# Altium schematic sheet FEV-60_IO_Board.SchDoc
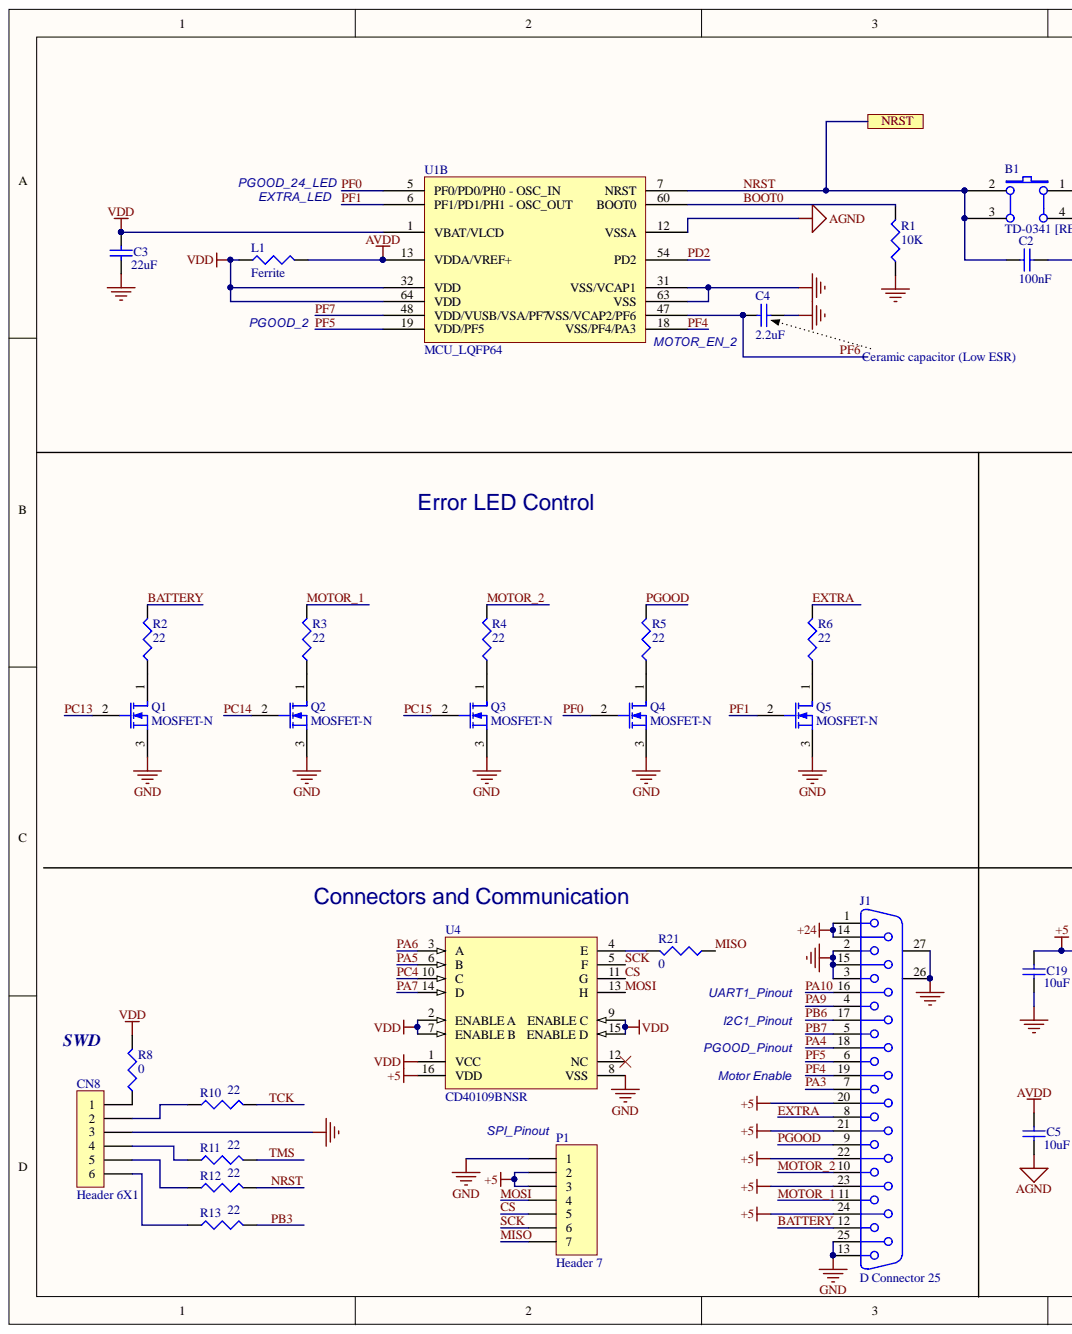
[diagram p0: FEV-60_IO_Board.SchDoc, left]
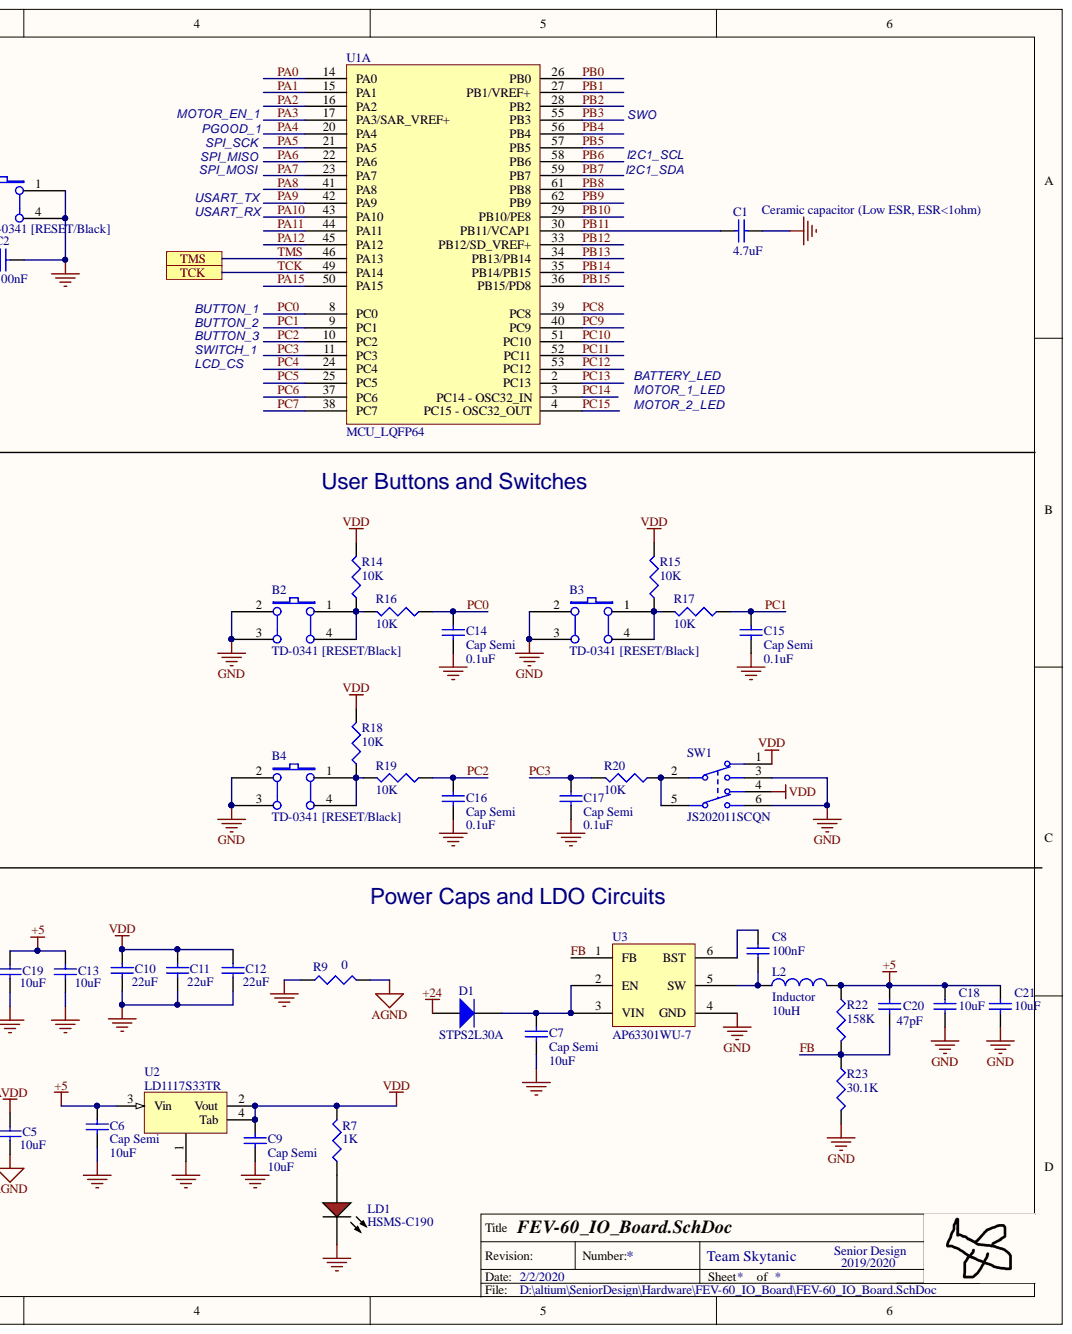
[diagram p1: FEV-60_IO_Board.SchDoc, right]

## Parts
B1  TD-0341 [RESET/Black]  [Button]
B2  TD-0341 [RESET/Black]  [Button]
B3  TD-0341 [RESET/Black]  [Button]
B4  TD-0341 [RESET/Black]  [Button]
C1  value 4.7uF  [1608[0603]]  (hidden comment: Cap Semi)
C2  value 100nF  [1608[0603]]  (hidden comment: Cap Semi)
C3  value 22uF  [1608[0603]]  (hidden comment: Cap Semi)
C4  value 2.2uF  [1608[0603]]  (hidden comment: Cap Semi)
C5  value 10uF  [1608[0603]]  (hidden comment: Cap Semi)
C6  Cap Semi  value 10uF  [1608[0603]]
C7  Cap Semi  value 10uF  [1608[0603]]
C8  value 100nF  [1608[0603]]  (hidden comment: Cap Semi)
C9  Cap Semi  value 10uF  [1608[0603]]
C10  value 22uF  [1608[0603]]  (hidden comment: Cap Semi)
C11  value 22uF  [1608[0603]]  (hidden comment: Cap Semi)
C12  value 22uF  [1608[0603]]  (hidden comment: Cap Semi)
C13  value 10uF  [1608[0603]]  (hidden comment: Cap Semi)
C14  Cap Semi  value 0.1uF  [1608[0603]]
C15  Cap Semi  value 0.1uF  [1608[0603]]
C16  Cap Semi  value 0.1uF  [1608[0603]]
C17  Cap Semi  value 0.1uF  [1608[0603]]
C18  value 10uF  [1608[0603]]  (hidden comment: Cap Semi)
C19  value 10uF  [1608[0603]]  (hidden comment: Cap Semi)
C20  value 47pF  [1608[0603]]  (hidden comment: Cap Semi)
C21  value 10uF  [1608[0603]]  (hidden comment: Cap Semi)
CN8  Header 6X1  [HDR1X6]
D1  STPS2L30A  [STPS2L30A]
J1  D Connector 25  [DSUB1.385-2H25A]
L1  Ferrite  [J1-0603]
L2  Inductor  value 10uH  [74404084100]
LD1  HSMS-C190  [AVAG-HSMX-C190_V]
P1  Header 7  [HDR1X7]
Q1  MOSFET-N  [RK7002BMT116]
Q2  MOSFET-N  [RK7002BMT116]
Q3  MOSFET-N  [RK7002BMT116]
Q4  MOSFET-N  [RK7002BMT116]
Q5  MOSFET-N  [RK7002BMT116]
R1  value 10K  [J1-0603]  (hidden comment: Res3)
R2  value 22  [J1-0603]  (hidden comment: Res3)
R3  value 22  [J1-0603]  (hidden comment: Res3)
R4  value 22  [J1-0603]  (hidden comment: Res3)
R5  value 22  [J1-0603]  (hidden comment: Res3)
R6  value 22  [J1-0603]  (hidden comment: Res3)
R7  value 1K  [J1-0603]  (hidden comment: Res3)
R8  value 0  [J1-0603]  (hidden comment: Res3)
R9  value 0  [J1-0603]  (hidden comment: Res3)
R10  value 22  [J1-0603]  (hidden comment: Res3)
R11  value 22  [J1-0603]  (hidden comment: Res3)
R12  value 22  [J1-0603]  (hidden comment: Res3)
R13  value 22  [J1-0603]  (hidden comment: Res3)
R14  value 10K  [J1-0603]  (hidden comment: Res3)
R15  value 10K  [J1-0603]  (hidden comment: Res3)
R16  value 10K  [J1-0603]  (hidden comment: Res3)
R17  value 10K  [J1-0603]  (hidden comment: Res3)
R18  value 10K  [J1-0603]  (hidden comment: Res3)
R19  value 10K  [J1-0603]  (hidden comment: Res3)
R20  value 10K  [J1-0603]  (hidden comment: Res3)
R21  value 0  [J1-0603]  (hidden comment: Res3)
R22  value 158K  [J1-0603]  (hidden comment: Res3)
R23  value 30.1K  [J1-0603]  (hidden comment: Res3)
SW1  JS202011SCQN  [CKCP-JS202011SCQN-6_V]
U1  MCU_LQFP64  [STM32F030R8]  (parts A,B)
U2  LD1117S33TR  [LD1117S33TR]
U3  AP63301WU-7  [AP63301WU-7]
U4  CD40109BNSR  [NS0016A_N]

## Ports
NRST, TCK, TMS

## Nets
+5: C6.2 C13.2 C18.2 C19.2 C20.2 C21.2 J1.20 J1.21 J1.22 J1.23 J1.24 L2.2 P1.2 P1.3 R22.1 U2.3(Vin) U4.16(VDD)
+24: D1.1 J1.1 J1.14
AGND: C5.1 R9.2 U1.12(VSSA)
AVDD: C5.2 L1.2 U1.13(VDDA/VREF+)
GND: B1.1 B1.4 B2.2 B2.3 B3.2 B3.3 B4.2 B4.3 C1.1 C2.1 C3.1 C4.1 C6.1 C7.1 C9.1 C10.1 C11.1 C12.1 C13.1 C14.1 C15.1 C16.1 C17.1 C18.1 C19.1 C21.1 CN8.3 J1.2 J1.3 J1.13 J1.15 J1.25 J1.26 J1.27 LD1.2 P1.1 Q1.3 Q2.3 Q3.3 Q4.3 Q5.3 R1.1 R9.1 R23.2 SW1.3 SW1.6 U1.31(VSS/VCAP1) U1.63(VSS) U2.1 U3.4(GND) U4.8(VSS)
VDD: C3.2 C9.2 C10.2 C11.2 C12.2 L1.1 R7.2 R8.2 R14.2 R15.2 R18.2 SW1.1 SW1.4 U1.1(VBAT/VLCD) U1.32(VDD) U1.64(VDD) U2.2(Vout) U2.4(Tab) U4.1(VCC) U4.2(ENABLE_A) U4.7(ENABLE_B) U4.9(ENABLE_C) U4.15(ENABLE_D)
BATTERY: J1.12 R2.2
BOOT0: R1.2 U1.60(BOOT0)
CS: P1.5 U4.11(G)
EXTRA: J1.8 R6.2
FB: C20.1 R22.2 R23.1 U3.1(FB)
MISO: P1.7 R21.1
MOSI: P1.4 U4.13(H)
MOTOR_1: J1.11 R3.2
MOTOR_2: J1.10 R4.2
NRST: B1.2 B1.3 C2.2 R12.2 U1.7(NRST) ; port NRST
PA0: U1.14(PA0)
PA1: U1.15(PA1)
PA2: U1.16(PA2)
PA3: J1.7 U1.17(PA3/SAR_VREF+)
PA4: J1.18 U1.20(PA4)
PA5: U1.21(PA5) U4.6(B)
PA6: U1.22(PA6) U4.3(A)
PA7: U1.23(PA7) U4.14(D)
PA8: U1.41(PA8)
PA9: J1.4 U1.42(PA9)
PA10: J1.16 U1.43(PA10)
PA11: U1.44(PA11)
PA12: U1.45(PA12)
PA15: U1.50(PA15)
PB0: U1.26(PB0)
PB1: U1.27(PB1/VREF+)
PB2: U1.28(PB2)
PB3: R13.2 U1.55(PB3)
PB4: U1.56(PB4)
PB5: U1.57(PB5)
PB6: J1.17 U1.58(PB6)
PB7: J1.5 U1.59(PB7)
PB8: U1.61(PB8)
PB9: U1.62(PB9)
PB10: U1.29(PB10/PE8)
PB11: C1.2 U1.30(PB11/VCAP1)
PB12: U1.33(PB12/SD_VREF+)
PB13: U1.34(PB13/PB14)
PB14: U1.35(PB14/PB15)
PB15: U1.36(PB15/PD8)
PC0: C14.2 R16.1 U1.8(PC0)
PC1: C15.2 R17.1 U1.9(PC1)
PC2: C16.2 R19.1 U1.10(PC2)
PC3: C17.2 R20.2 U1.11(PC3)
PC4: U1.24(PC4) U4.10(C)
PC5: U1.25(PC5)
PC6: U1.37(PC6)
PC7: U1.38(PC7)
PC8: U1.39(PC8)
PC9: U1.40(PC9)
PC10: U1.51(PC10)
PC11: U1.52(PC11)
PC12: U1.53(PC12)
PC13: Q1.2 U1.2(PC13)
PC14: Q2.2 U1.3(PC14_-_OSC32_IN)
PC15: Q3.2 U1.4
PD2: U1.54(PD2)
PF0: Q4.2 U1.5
PF1: Q5.2 U1.6
PF4: J1.19 U1.18(VSS/PF4/PA3)
PF5: J1.6 U1.19(VDD/PF5)
PF6: C4.2 U1.47(VSS/VCAP2/PF6)
PF7: U1.48(VDD/VUSB/VSA/PF7)
PGOOD: J1.9 R5.2
SCK: P1.6 U4.5(F)
TCK: R10.2 U1.49(PA14) ; port TCK
TMS: R11.2 U1.46(PA13) ; port TMS
NetB2_1: B2.1 B2.4 R14.1 R16.2
NetB3_1: B3.1 B3.4 R15.1 R17.2
NetB4_1: B4.1 B4.4 R18.1 R19.2
NetC7_2: C7.2 D1.2 U3.2(EN) U3.3(VIN)
NetC8_1: C8.1 L2.1 U3.5(SW)
NetC8_2: C8.2 U3.6(BST)
NetCN8_1: CN8.1 R8.1
NetCN8_2: CN8.2 R10.1
NetCN8_4: CN8.4 R11.1
NetCN8_5: CN8.5 R12.1
NetCN8_6: CN8.6 R13.1
NetLD1_1: LD1.1 R7.1
NetQ1_1: Q1.1 R2.1
NetQ2_1: Q2.1 R3.1
NetQ3_1: Q3.1 R4.1
NetQ4_1: Q4.1 R5.1
NetQ5_1: Q5.1 R6.1
NetR20_1: R20.1 SW1.2 SW1.5
NetR21_2: R21.2 U4.4(E)

Not connected: U4 12(NC)

## Library data of the parts
B1-B4 = SW PUSHBUTTON: SE PUSHBUTTON; Component Kind: Standard; Library Name: Documents.SCHLIB
C1-C21 = Cap Semi: Capacitor (Semiconductor SIM Model); Component Kind: Standard; LatestRevisionDate: 29-May-2009; LatestRevisionNote: IPC-7351 Footprint Added.; Library Name: Miscellaneous Devices.IntLib; PackageDescription: Chip Capacitor; PackageReference: C1206; Published: 8-Jun-2000; Signal Integrity: Cap Semi; Simulation: CAP; Publisher: Altium Limited
CN8 = Header 6X1: Header, 6-Pin, Single row; Component Kind: Standard; LatestRevisionDate: 17-Jul-2002; LatestRevisionNote: Re-released for DXP Platform.; Library Name: Nucleo_64.SCHLIB; Signal Integrity: Connector; Publisher: Altium Limited
D1 = STPS2L30A: Manuf_1: STM; Manuf_Part_1: BAT60JFILM; ECCN_US/EU: EAR99/NEC; Provided: ST; Component Kind: Standard; Description: STPS2L30A; Distrib: ST; Library Name: Nucleo_64.SCHLIB; Order: BAT60JFILM; Revision: July-2002: Re-released for DXP Platform.
J1 = D Connector 25: Receptacle Assembly, 25 Position, Right Angle; PackageDocument: U; Positions: 25; Component Kind: Standard; DatasheetDocument: 19-Mar-1999; Gender: Receptacle; LatestRevisionDate: 17-Jul-2002; LatestRevisionNote: Re-released for DXP Platform.; Library Name: Miscellaneous Connectors.IntLib; Orientation: Right Angle; PackageReference: 787202; Published: 15-Jun-2001; Signal Integrity: Connector; Publisher: Altium Limited
L1 R1-R23 = Res3: Resistor; Component Kind: Standard; LatestRevisionDate: 29-May-2009; LatestRevisionNote: IPC-7351 Footprint Added.; Library Name: Miscellaneous Devices.IntLib; PackageDescription: Chip Resistor; PackageReference: J1-0603; Published: 8-Jun-2000; Signal Integrity: Res; Simulation: RESISTOR; Publisher: Altium Limited
L2 = Inductor: Code_IEC: 1005; Code_JEITA: 0402; Component Kind: Standard; Description: Inductor; LatestRevisionDate: 29-May-2009; LatestRevisionNote: IPC-7351 Footprint Added.; Library Name: Miscellaneous Devices.IntLib; PackageDescription: Chip Inductor; PackageReference: 0402-A; Published: 8-Jun-2000; Signal Integrity: Inductor; Simulation: INDUCTOR; Publisher: Altium Limited
LD1 = CMP-2000-06427-1: LED Uni-Color Red, 52 mW, 20 mA, -40 to 85 degC, 2-Pin SMD, RoHS, Tape and Reel; Manufacturer: Avago; Chip Material: GaP; DELETED: Compliant; Dominant Wave Length: 626nm; Forward Current: 25mA; Forward Voltage: 2.6V; High Brightness: No; Lens Type: ColorlessDiffused; Luminous Intensity: 10mcd; Main Category: ChipLED; Number of  Elements: 1; Peak Wavelength: 630nm; Power Dissipation: 52W; Product Depth (mm): 0.8mm; Product Length (mm): 1.6mm; Reverse Voltage: 5V; Shape Type: Rectangular; Test Current (It): 20mA; Viewing Angle: 170deg; Component Kind: Standard; Datasheet URL: http://docs.avagotech.com/docs/AV02-0551EN; Datasheet Version: 03/2012; Emitting Color: Red; Lens Dimensions: 1x0.8x0.5mm; Library Name: Altium Content Vault; Lum Intensity: 10mcd; Manufacturer URL: http://www.avagotech.com/; Mounting: SurfaceMount; Mounting Technology: SurfaceMount; Operating Temperature Classification: Industrial; Operating Temp Range: -40Cto85C; Package Description: 2-Pin Surface Mount Device, Body 1.6 x 0.8 mm, Height 0.9 mm; Package Reference: HSMX-C190; Package Type: ChipLed; Packaging: TapeandReel; Pin Count: 2; Product Height (mm): 0.8mm; Rad Hardened: No; Type: Uni-Color
P1 = Header 7: Header, 7-Pin; Component Kind: Standard; LatestRevisionDate: 17-Jul-2002; LatestRevisionNote: Re-released for DXP Platform.; Library Name: Miscellaneous Connectors.IntLib; Signal Integrity: Connector; Publisher: Altium Limited
Q1-Q5 = MOSFET-N: N-Channel MOSFET; Component Kind: Standard; LatestRevisionDate: 17-Jul-2002; LatestRevisionNote: Re-released for DXP Platform.; Library Name: Miscellaneous Devices.IntLib; PackageDescription: TO, Flat Index; 3 In-Line, Axial Leads; Body Dia. 4.6mm; Lead Dia. 0.5 mm (max); PackageReference: E3; PackageVersion: 1995/1996; Published: 8-Jun-2000; Simulation: NMOS; Publisher: Altium Limited
SW1 = CMP-2000-05087-1: Switch Slide DPDT, 0.3 A, 6 V, -40 to 85 degC, 6-Pin SMD, RoHS, Tape and Reel; Manufacturer: C&K Components; Actuator Color: Black; Actuator Material: Nylon4/6; Actuator Style: TopSlide; Body Orientation: Straight; Contact Rating @ Voltage: 0.3@6VDCA; COO: US; Dielectric Strength: 500VAC; Electrical Life: 15000Cycles; Maximum Contact Resistance: 70mOhm; Maximum DC Voltage Rating: 6V; Minimum Insulation Resistance: 100MOhm; Base Material: N/A; Component Kind: Standard; Contact Material: CopperAlloy; Contact Plating: Silver; Datasheet URL: http://datasheet.octopart.com/JS202011SCQN-C%26K-Components-datasheet-62100994.pdf; Datasheet Version: Sep-15; Dimension: 9x7.5x5.5mm; ECCN: EAR99; Family: JS; Housing Material: Nylon4/6; HTS: 8536509050; Library Name: Altium Content Vault; Manufacturer URL: http://www.ckswitches.com; Maximum AC Voltage Rating: N/A; Mechanical Life: N/A; Mounting: SurfaceMount,GullWing; Operating Temperature: -40to85C; Packaging: TapeandReel; Part No: JS202011SCQN; Pole Throw Configuration: DPDT; Product Weight: N/A; RoHS: Yes; Seal Description: N/A; Seal Location: N/A; Supplier: C&KComponents; Supplier Standard Pack: 5,000; Switch Configuration: N/A; Type: Sub-Miniature
U2 = LD1117: Component Kind: Standard; Library Name: mb1045.SCHLIB
U3 = AP63301WU-7: Buck Switching Regulator IC Positive Adjustable 0.8V 1 Output 3A SOT-23-6 Thin, TSOT-23-6; Component Kind: Standard; ComponentLink1Description: Digikey; ComponentLink1URL: https://www.digikey.com/product-detail/en/diodes-incorporated/AP63301WU-7/31-AP63301WU-7CT-ND/10492238; Library Name: 2020-01-14_19-20-40.SchLib
U4 = CMP-1527-00005-2: CMOS Quad Low-to-High Voltage Level Shifter, 3 to 18 V, -55 to 125 degC, 16-Pin SO (NS16), RoHS, Tape and Reel; Manufacturer: Texas Instruments; Number of Bits: 4; Propagation Delay: 240 ns Max.; VSS: 3 to 18 V; Component Kind: Standard; ComponentLink1Description: Manufacturer URL; ComponentLink1URL: www.ti.com; ComponentLink2Description: Datasheet; ComponentLink2URL: http://www.ti.com/lit/ds/symlink/cd40109b.pdf; ComponentLink3Description: Package Specification; ComponentLink3URL: http://www.ti.com/lit/ml/msop002a/msop002a.pdf; DatasheetVersion: SCHS099B; Library Name: Altium Content Vault; Mounting Technology: Surface Mount; Number of Channels: 4; Number of Outputs: 4; PackageDescription: 16-Pin Plastic Small Outline Package, Body 10.2 x 5.3 mm, Pitch 1.27 mm; Package Reference: NS0016A; PackageVersion: 4040062/C; Packing: Tape and Reel; PartNumber: CD40109BNSR; RoHS: TRUE; Temperature Range: -55 to 125 degC
U1 = MCU_LQFP64: Component Kind: Standard; Library Name: Nucleo_64.SCHLIB; Signal Integrity: Guessed Model
